# Altium schematic sheet Path Switch.SchDoc("Path Switch")
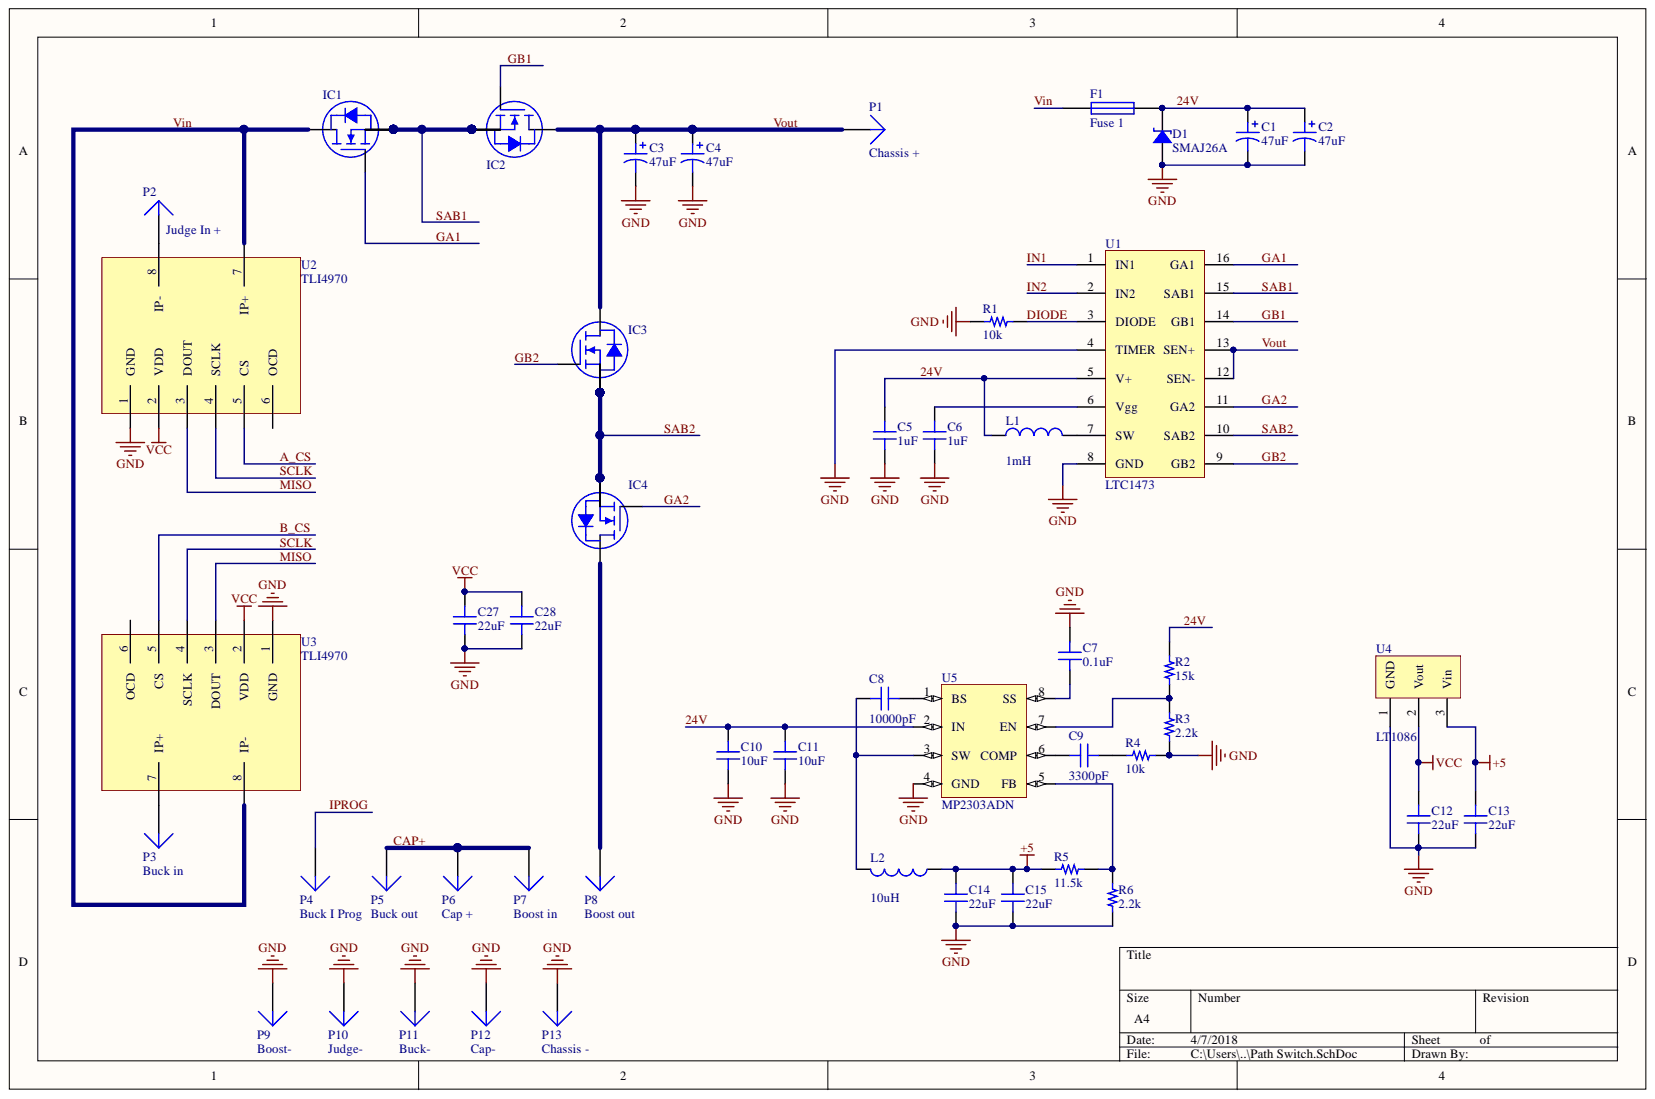
[diagram p0: Path Switch.SchDoc]

## Parts
C1  47uF  [CAP POL 2917/7343]
C2  47uF  [CAP POL 2917/7343]
C3  47uF  [CAP ALUM 10.3MM]
C4  47uF  [CAP ALUM 10.3MM]
C5  1uF  [CAP 0603/1608]
C6  1uF  [CAP 0603/1608]
C7  0.1uF  [CAP 0603/1608]
C8  10000pF  [CAP 0603/1608]
C9  3300pF  [CAP 0603/1608]
C10  10uF  [0805 tight]
C11  10uF  [0805 tight]
C12  22uF  [CAP 0603/1608]
C13  22uF  [CAP 0603/1608]
C14  22uF  [CAP 0603/1608]
C15  22uF  [CAP 0603/1608]
C27  22uF  [CAP 0603/1608]
C28  22uF  [CAP 0603/1608]
D1  SMAJ26A  [Bourns DO214AC SMA]
F1  Fuse 1  [Resetable fuse MF-SM050]
IC1  IPT020N10N3ATMA1  [INFINEON PG-HSOF-8-1]  (value not drawn)
IC2  IPT020N10N3ATMA1  [INFINEON PG-HSOF-8-1]  (value not drawn)
IC3  IPT020N10N3ATMA1  [INFINEON PG-HSOF-8-1]  (value not drawn)
IC4  IPT020N10N3ATMA1  [INFINEON PG-HSOF-8-1]  (value not drawn)
L1  value 1mH  [1812]  (hidden comment: Inductor)
L2  value 10uH  [VLP8040]  (hidden comment: Inductor)
P1  Chassis +  [6.5 Banana f via]
P2  Judge In +  [6.5 Banana F]
P3  Buck in  [6.5 Banana F]
P4  Buck I Prog  [PIN1]
P5  Buck out  [6.5 Banana F]
P6  Cap +  [6.5 Banana F]
P7  Boost in  [6.5 Banana F]
P8  Boost out  [6.5 Banana f via]
P9  Boost-  [6.5 Banana f via]
P10  Judge-  [6.5 Banana f via]
P11  Buck-  [6.5 Banana f via]
P12  Cap-  [6.5 Banana f via]
P13  Chassis -  [6.5 Banana f via]
R1  10k  [RES 0603/1608]
R2  15k  [RES 0603/1608]
R3  2.2k  [RES 0603/1608]
R4  10k  [RES 0603/1608]
R5  11.5k  [RES 0603/1608]
R6  2.2k  [RES 0603/1608]
U1  LTC1473  [16P 0.635mm SSOP]
U2  TLI4970  [PG-TISON-8-1]
U3  TLI4970  [PG-TISON-8-1]
U4  LT1086  [DPAK254P1394X457-4N]
U5  MP2303ADN  [SOP127P600X170_HS-9N]

## Nets
+5: C13.1 C14.1 C15.1 L2.1 R5.1 U4.3
GND: C1.2 C2.2 C3.2 C4.2 C5.1 C6.1 C7.2 C10.1 C11.1 C12.2 C13.2 C14.2 C15.2 C27.2 C28.2 D1.1 P9.1 P10.1 P11.1 P12.1 P13.1 R1.1 R3.2 R4.2 R6.1 U1.4(TIMER) U1.8(GND) U2.1(GND) U3.1(GND) U4.1 U5.4(GND)
VCC: C12.1 C27.1 C28.1 U2.2(VDD) U3.2(VDD) U4.2
24V: C1.1 C2.1 C5.2 C10.2 C11.2 D1.2 F1.2 L1.1 R2.1 U1.5(V+) U5.2(IN)
A_CS: U2.5(CS)
B_CS: U3.5(CS)
CAP+: P5.1 P6.1 P7.1
DIODE: R1.2 U1.3(DIODE)
GA1: IC1.1 U1.16(GA1)
GA2: IC4.1 U1.11(GA2)
GB1: IC2.1 U1.14(GB1)
GB2: IC3.1 U1.9(GB2)
IN1: U1.1(IN1)
IN2: U1.2(IN2)
IPROG: P4.1
MISO: U2.3(DOUT) U3.3(DOUT)
SAB1: IC1.2 IC1.3 IC1.4 IC1.5 IC1.6 IC1.7 IC1.8 IC2.2 IC2.3 IC2.4 IC2.5 IC2.6 IC2.7 IC2.8 U1.15(SAB1)
SAB2: IC3.2 IC3.3 IC3.4 IC3.5 IC3.6 IC3.7 IC3.8 IC4.2 IC4.3 IC4.4 IC4.5 IC4.6 IC4.7 IC4.8 U1.10(SAB2)
SCLK: U2.4(SCLK) U3.4(SCLK)
Vin: F1.1 IC1.9 U2.7(IP+) U3.8(IP-)
Vout: C3.1 C4.1 IC2.9 IC3.9 P1.1 U1.12(SEN-) U1.13(SEN+)
NetC6_2: C6.2 U1.6(Vgg)
NetC7_1: C7.1 U5.8(SS)
NetC8_1: C8.1 L2.2 U5.3(SW)
NetC8_2: C8.2 U5.1(BS)
NetC9_1: C9.1 U5.6(COMP)
NetC9_2: C9.2 R4.1
NetIC4_9: IC4.9 P8.1
NetL1_2: L1.2 U1.7(SW)
NetP2_1: P2.1 U2.8(IP-)
NetP3_1: P3.1 U3.7(IP+)
NetR2_2: R2.2 R3.1 U5.7(EN)
NetR5_2: R5.2 R6.2 U5.5(FB)

Not connected: U2 6(OCD); U3 6(OCD)

## Library data of the parts
C1 C2 = 593D476X9016D2TE3: CAP TANT 47UF 16V 10% 2917; Manufacturer: Vishay Sprague; Type: General Purpose; TemperatureRange: -55C ~ 125C; Database Table Name: Capacitor - Tantalum; Voltage: 16V; Value: 47uF; Tolerance: 10%; Supplier Part Number 1: 718-1108-2-ND; Supplier 1: Digi-Key; Price: 0.4371; Part Number: 593D476X9016D2TE3; PartId: 6883; Library Name: Celestial Library Database.DbLib; Device Package: 2917 (7343 Metric); ComponentLink2URL: http://digikey.com/product-detail/en/593D476X9016D2TE3/718-1108-2-ND/1559460; ComponentLink2Description: Digi-Key listing; ComponentLink1URL: http://www.vishay.com/docs/40005/593d.pdf; ComponentLink1Description: Datasheet; Component Kind: Standard
C3 C4 = 35SVPD47M: CAP POLYMER 47UF 20% 35V SMD; Manufacturer: Panasonic Electronic Components; Type: General Purpose; TemperatureRange: -55C ~ 125C; Ripple Current: 3.65A; Lifetime @ Temp: 2000 Hrs @ 125C; Database Table Name: Capacitor - Aluminium Polymer; Voltage: 35V; Value: 47uF; Tolerance: 20%; Supplier Part Number 1: PCE5080TR-ND; Supplier 1: Digi-Key; Price: 1.5882; Part Number: 35SVPD47M; PartId: 7714; Library Name: Celestial Library Database.DbLib; Impedance: -; Device Package: Radial, Can - SMD; ComponentLink2URL: http://digikey.com/product-detail/en/35SVPD47M/PCE5080TR-ND/4833026; ComponentLink2Description: Digi-Key listing; ComponentLink1URL: http://media.digikey.com/pdf/Data%20Sheets/Panasonic%20Capacitors%20PDFs/SVPD_Series.pdf; ComponentLink1Description: Datasheet; Component Kind: Standard
C5 C6 = C1608X7R1A105K080AC: CAP CER 1UF 10V X7R 0603; Manufacturer: TDK Corporation; Tolerance: 10%; TemperatureRange: -55C ~ 125C; Database Table Name: Capacitor - Ceramic; Voltage: 10V; Value: 1uF; Type: -; TemperatureCoefficient: X7R; Supplier Part Number 1: 445-5138-1-ND; Supplier 1: Digi-Key; Price: 0.14; Part Number: C1608X7R1A105K080AC; PartId: 4035; Library Name: Celestial Library Database.DbLib; Device Package: 0603 (1608 Metric); ComponentLink2URL: http://digikey.com/product-detail/en/C1608X7R1A105K080AC/445-5138-1-ND/2093753; ComponentLink2Description: Digi-Key listing; ComponentLink1URL: https://product.tdk.com/info/en/catalog/datasheets/mlcc_commercial_general_en.pdf; ComponentLink1Description: Datasheet; Component Kind: Standard
C7 = C1608X7R1E104K080AA: CAP CER 0.1UF 25V X7R 0603; Manufacturer: TDK Corporation; Tolerance: 10%; TemperatureRange: -55C ~ 125C; Database Table Name: Capacitor - Ceramic; Voltage: 25V; Value: 0.1uF; Type: -; TemperatureCoefficient: X7R; Supplier Part Number 1: 445-1316-1-ND; Supplier 1: Digi-Key; Price: 0.14; Part Number: C1608X7R1E104K080AA; PartId: 4029; Library Name: Celestial Library Database.DbLib; Device Package: 0603 (1608 Metric); ComponentLink2URL: http://digikey.com/product-detail/en/C1608X7R1E104K080AA/445-1316-1-ND/567697; ComponentLink2Description: Digi-Key listing; ComponentLink1URL: https://product.tdk.com/info/en/catalog/datasheets/mlcc_commercial_general_en.pdf; ComponentLink1Description: Datasheet; Component Kind: Standard
C8 = C1608X7R2A103K080AA: CAP CER 10000PF 100V X7R 0603; Manufacturer: TDK Corporation; Tolerance: 10%; TemperatureRange: -55C ~ 125C; Database Table Name: Capacitor - Ceramic; Voltage: 100V; Value: 10000pF; Type: -; TemperatureCoefficient: X7R; Supplier Part Number 1: 445-1304-1-ND; Supplier 1: Digi-Key; Price: 0.14; Part Number: C1608X7R2A103K080AA; PartId: 4033; Library Name: Celestial Library Database.DbLib; Device Package: 0603 (1608 Metric); ComponentLink2URL: http://digikey.com/product-detail/en/C1608X7R2A103K080AA/445-1304-1-ND/567706; ComponentLink2Description: Digi-Key listing; ComponentLink1URL: https://product.tdk.com/info/en/catalog/datasheets/mlcc_commercial_midvoltage_en.pdf; ComponentLink1Description: Datasheet; Component Kind: Standard
C9 = C1608X7R2A332K080AA: CAP CER 3300PF 100V X7R 0603; Manufacturer: TDK Corporation; Tolerance: 10%; TemperatureRange: -55C ~ 125C; Database Table Name: Capacitor - Ceramic; Voltage: 100V; Value: 3300pF; Type: -; TemperatureCoefficient: X7R; Supplier Part Number 1: 445-4422-1-ND; Supplier 1: Digi-Key; Price: 0.14; Part Number: C1608X7R2A332K080AA; PartId: 4081; Library Name: Celestial Library Database.DbLib; Device Package: 0603 (1608 Metric); ComponentLink2URL: http://digikey.com/product-detail/en/C1608X7R2A332K080AA/445-4422-1-ND/2042218; ComponentLink2Description: Digi-Key listing; ComponentLink1URL: https://product.tdk.com/info/en/catalog/datasheets/mlcc_commercial_midvoltage_en.pdf; ComponentLink1Description: Datasheet; Component Kind: Standard
C10 C11 = C2012X5R0J106K125AB: CAP CER 10UF 6.3V X5R 0805; Manufacturer: TDK Corporation; Tolerance: 10%; TemperatureRange: -55C ~ 85C; Database Table Name: Capacitor - Ceramic; Voltage: 6.3V; Value: 10uF; Type: -; TemperatureCoefficient: X5R; Supplier Part Number 1: 445-4113-2-ND; Supplier 1: Digi-Key; Price: 0.0486; Part Number: C2012X5R0J106K125AB; PartId: 4503; Library Name: Celestial Library Database.DbLib; Device Package: 0805 (2012 Metric); ComponentLink2URL: http://digikey.com/product-detail/en/C2012X5R0J106K125AB/445-4113-2-ND/1975460; ComponentLink2Description: Digi-Key listing; ComponentLink1URL: https://product.tdk.com/info/en/catalog/datasheets/mlcc_commercial_general_en.pdf; ComponentLink1Description: Datasheet; Component Kind: Standard
C12-C15 C27 C28 = C1608X5R0J226M080AC: CAP CER 22UF 6.3V X5R 0603; Manufacturer: TDK Corporation; Tolerance: 20%; TemperatureRange: -55C ~ 85C; Database Table Name: Capacitor - Ceramic; Voltage: 6.3V; Value: 22uF; TemperatureCoefficient: X5R; Supplier Part Number 1: 445-8028-2-ND; Supplier 1: Digi-Key; Price: 0.0816; Part Number: C1608X5R0J226M080AC; PartId: 12992; Library Name: Celestial Library Database.DbLib; Device Package: 0603 (1608 Metric); ComponentLink2URL: https://www.digikey.com/product-detail/en/tdk-corporation/C1608X5R0J226M080AC/445-8028-2-ND/2792254; ComponentLink2Description: Digi-Key Listing; ComponentLink1URL: https://product.tdk.com/info/en/catalog/datasheets/mlcc_commercial_general_en.pdf; ComponentLink1Description: Datasheet; Component Kind: Standard
D1 = SMAJ26A: TVS DIODE 26VWM 42.1VC DO214AC; Manufacturer: Bourns Inc.; Voltage (Reverse Standoff): 26V; Voltage (Clamping): 42.1V; Voltage (Breakdown): 28.9V; Unidirectional Channels: 1; Type: Zener; Power - Peak Pulse: 400W; Database Table Name: Diode - TVS; Current - Peak Pulse: 9.5A; Supplier Part Number 1: SMAJ26ABTR-ND; Supplier 1: Digi-Key; Price: 0.0928; Part Number: SMAJ26A; PartId: 14877; Operating Temperature: -55C ~ 150C (TJ); Library Name: Celestial Library Database.DbLib; Device Package: DO-214AC, SMA; ComponentLink2URL: https://www.digikey.com/product-detail/en/bourns-inc/SMAJ26A/SMAJ26ABTR-ND/2254571; ComponentLink2Description: Digi-Key Listing; ComponentLink1URL: http://www.bourns.com/docs/Product-Datasheets/SMAJ.pdf; ComponentLink1Description: Datasheet; Component Kind: Standard
F1 = Fuse 1: Fuse; Simulation: FUSE; Published: 8-Jun-2000; PackageReference: PIN-W2/E2.8; PackageDescription: Thru-Hole; 2 Leads; Library Name: Miscellaneous Devices.IntLib; LatestRevisionNote: Re-released for DXP Platform.; LatestRevisionDate: 17-Jul-2002; Component Kind: Standard; Publisher: Altium Limited
IC1-IC4 = IPT020N10N3ATMA1: MOSFET N-CH 100V 300A 8HSOF; Manufacturer: Infineon Technologies; Vgs(th) (Max) at Id: 3.5V @ 272uA; Rds On (Max) at Id, Vgs: 2 mOhm @ 150A, 10V; Power - Max: 375W; Input Capacitance (Ciss) at Vds: 11200pF @ 50V; Gate Charge (Qg): 156nC @ 10V; Database Table Name: N-Channel FET; Current Continuous Drain: 300A (Tc); Type: MOSFET N-Channel, Metal Oxide; Supplier Part Number 1: IPT020N10N3ATMA1TR-ND; Supplier 1: Digi-Key; Price: 3.3442; Part Number: IPT020N10N3ATMA1; PartId: 7970; Library Name: Celestial Library Database.DbLib; Features: Standard; Drain to Source Voltage (Vdss): 100V; Device Package: 8-PowerSFN; ComponentLink2URL: http://www.digikey.com/product-detail/en/IPT020N10N3ATMA1/IPT020N10N3ATMA1TR-ND/4571872; ComponentLink2Description: Digi-Key Listing; ComponentLink1URL: http://www.infineon.com/dgdl/IPT020N10N3_Rev1.1.pdf?folderId=db3a304313b8b5a60113cee8763b02d7&fileId=db3a30433e9d5d11013e9e58035b0158; ComponentLink1Description: Datasheet; Component Kind: Standard
L1 L2 = Inductor: Code_IEC: 1005; Simulation: INDUCTOR; Signal Integrity: Inductor; Published: 8-Jun-2000; PackageReference: 0402-A; PackageDescription: Chip Inductor; Library Name: Miscellaneous Devices.IntLib; LatestRevisionNote: IPC-7351 Footprint Added.; LatestRevisionDate: 29-May-2009; Description: Inductor; Component Kind: Standard; Code_JEITA: 0402; Publisher: Altium Limited
P1-P13 = Plug: Signal Integrity: Connector; Library Name: Miscellaneous Connectors.IntLib; LatestRevisionNote: Re-released for DXP Platform.; LatestRevisionDate: 17-Jul-2002; Description: Plug; Component Kind: Standard; Publisher: Altium Limited
R1 R4 = ERA-3APB103V: RES SMD 10K OHM 0.1% 1/10W 0603; Manufacturer: Panasonic Electronic Components; TemperatureRange: -55C ~ 155C; TemperatureCoefficient: 15ppm/C; PowerRating: 0.1W, 1/10W; Database Table Name: Resistor - Chip; Value: 10k; Type: Thin Film; Tolerance: 0.1%; Supplier Part Number 1: P10KAYTR-ND; Supplier 1: Digi-Key; Price: 0.2873; Part Number: ERA-3APB103V; PartId: 1475; Library Name: Celestial Library Database.DbLib; Device Package: 0603 (1608 Metric); ComponentLink2URL: http://digikey.com/product-detail/en/ERA-3APB103V/P10KAYTR-ND/3070622; ComponentLink2Description: Digi-Key listing; ComponentLink1URL: http://industrial.panasonic.com/lecs/www-data/pdf/AOA0000/AOA0000CE26.pdf; ComponentLink1Description: Datasheet; Component Kind: Standard
R2 = ERA-3AEB153V: RES SMD 15K OHM 0.1% 1/10W 0603; Manufacturer: Panasonic Electronic Components; TemperatureRange: -55C ~ 155C; TemperatureCoefficient: 25ppm/C; PowerRating: 0.1W, 1/10W; Database Table Name: Resistor - Chip; Value: 15k; Type: Thin Film; Tolerance: 0.1%; Supplier Part Number 1: P15KDBTR-ND; Supplier 1: Digi-Key; Price: 0.0653; Part Number: ERA-3AEB153V; PartId: 1449; Library Name: Celestial Library Database.DbLib; Device Package: 0603 (1608 Metric); ComponentLink2URL: http://digikey.com/product-detail/en/ERA-3AEB153V/P15KDBTR-ND/1465882; ComponentLink2Description: Digi-Key listing; ComponentLink1URL: http://industrial.panasonic.com/lecs/www-data/pdf/AOA0000/AOA0000CE26.pdf; ComponentLink1Description: Datasheet; Component Kind: Standard
R3 = ERA-3AEB222V: RES SMD 2.2K OHM 0.1% 1/10W 0603; Manufacturer: Panasonic Electronic Components; TemperatureRange: -55C ~ 155C; TemperatureCoefficient: 25ppm/C; PowerRating: 0.1W, 1/10W; Database Table Name: Resistor - Chip; Value: 2.2k; Type: Thin Film; Tolerance: 0.1%; Supplier Part Number 1: P2.2KDBTR-ND; Supplier 1: Digi-Key; Price: 0.0653; Part Number: ERA-3AEB222V; PartId: 1454; Library Name: Celestial Library Database.DbLib; Device Package: 0603 (1608 Metric); ComponentLink2URL: http://digikey.com/product-detail/en/ERA-3AEB222V/P2.2KDBTR-ND/1465862; ComponentLink2Description: Digi-Key listing; ComponentLink1URL: http://industrial.panasonic.com/lecs/www-data/pdf/AOA0000/AOA0000CE26.pdf; ComponentLink1Description: Datasheet; Component Kind: Standard
R5 = ERJ-3EKF1152V: RES SMD 11.5K OHM 1% 1/10W 0603; Manufacturer: Panasonic Electronic Components; TemperatureRange: -55C ~ 155C; TemperatureCoefficient: 100ppm/C; PowerRating: 0.1W, 1/10W; Database Table Name: Resistor - Chip; Value: 11.5k; Type: Thick Film; Tolerance: 1%; Supplier Part Number 1: P11.5KHTR-ND; Supplier 1: Digi-Key; Price: 0.0038; Part Number: ERJ-3EKF1152V; PartId: 1206; Library Name: Celestial Library Database.DbLib; Device Package: 0603 (1608 Metric); ComponentLink2URL: http://digikey.com/product-detail/en/ERJ-3EKF1152V/P11.5KHTR-ND/196087; ComponentLink2Description: Digi-Key listing; ComponentLink1URL: http://industrial.panasonic.com/www-cgi/jvcr13pz.cgi?E+PZ+3+AOA0002+ERJ3EKF1152V+7+WW; ComponentLink1Description: Datasheet; Component Kind: Standard
R6 = ERA-3ARB222V: RES SMD 2.2K OHM 0.1% 1/10W 0603; Manufacturer: Panasonic Electronic Components; TemperatureRange: -55C ~ 155C; TemperatureCoefficient: 10ppm/C; PowerRating: 0.1W, 1/10W; Database Table Name: Resistor - Chip; Value: 2.2k; Type: Thin Film; Tolerance: 0.1%; Supplier Part Number 1: P2.2KBDTR-ND; Supplier 1: Digi-Key; Price: 0.2661; Part Number: ERA-3ARB222V; PartId: 1804; Library Name: Celestial Library Database.DbLib; Device Package: 0603 (1608 Metric); ComponentLink2URL: http://digikey.com/product-detail/en/ERA-3ARB222V/P2.2KBDTR-ND/3073651; ComponentLink2Description: Digi-Key listing; ComponentLink1URL: http://industrial.panasonic.com/lecs/www-data/pdf/AOA0000/AOA0000CE26.pdf; ComponentLink1Description: Datasheet; Component Kind: Standard
U1 = LTC1473: Library Name: Power Parts.SchLib; Component Kind: Standard
U2 U3 = TLI4970: Library Name: Power Parts.SchLib; Component Kind: Standard
U4 = LT1086: Library Name: Power Parts.SchLib; Component Kind: Standard
U5 = MP2303ADN: Library Name: Power Parts.SchLib; Component Kind: Standard
